# Altium schematic sheet Triangular_Wave.SchDoc(Triangular_Wave)
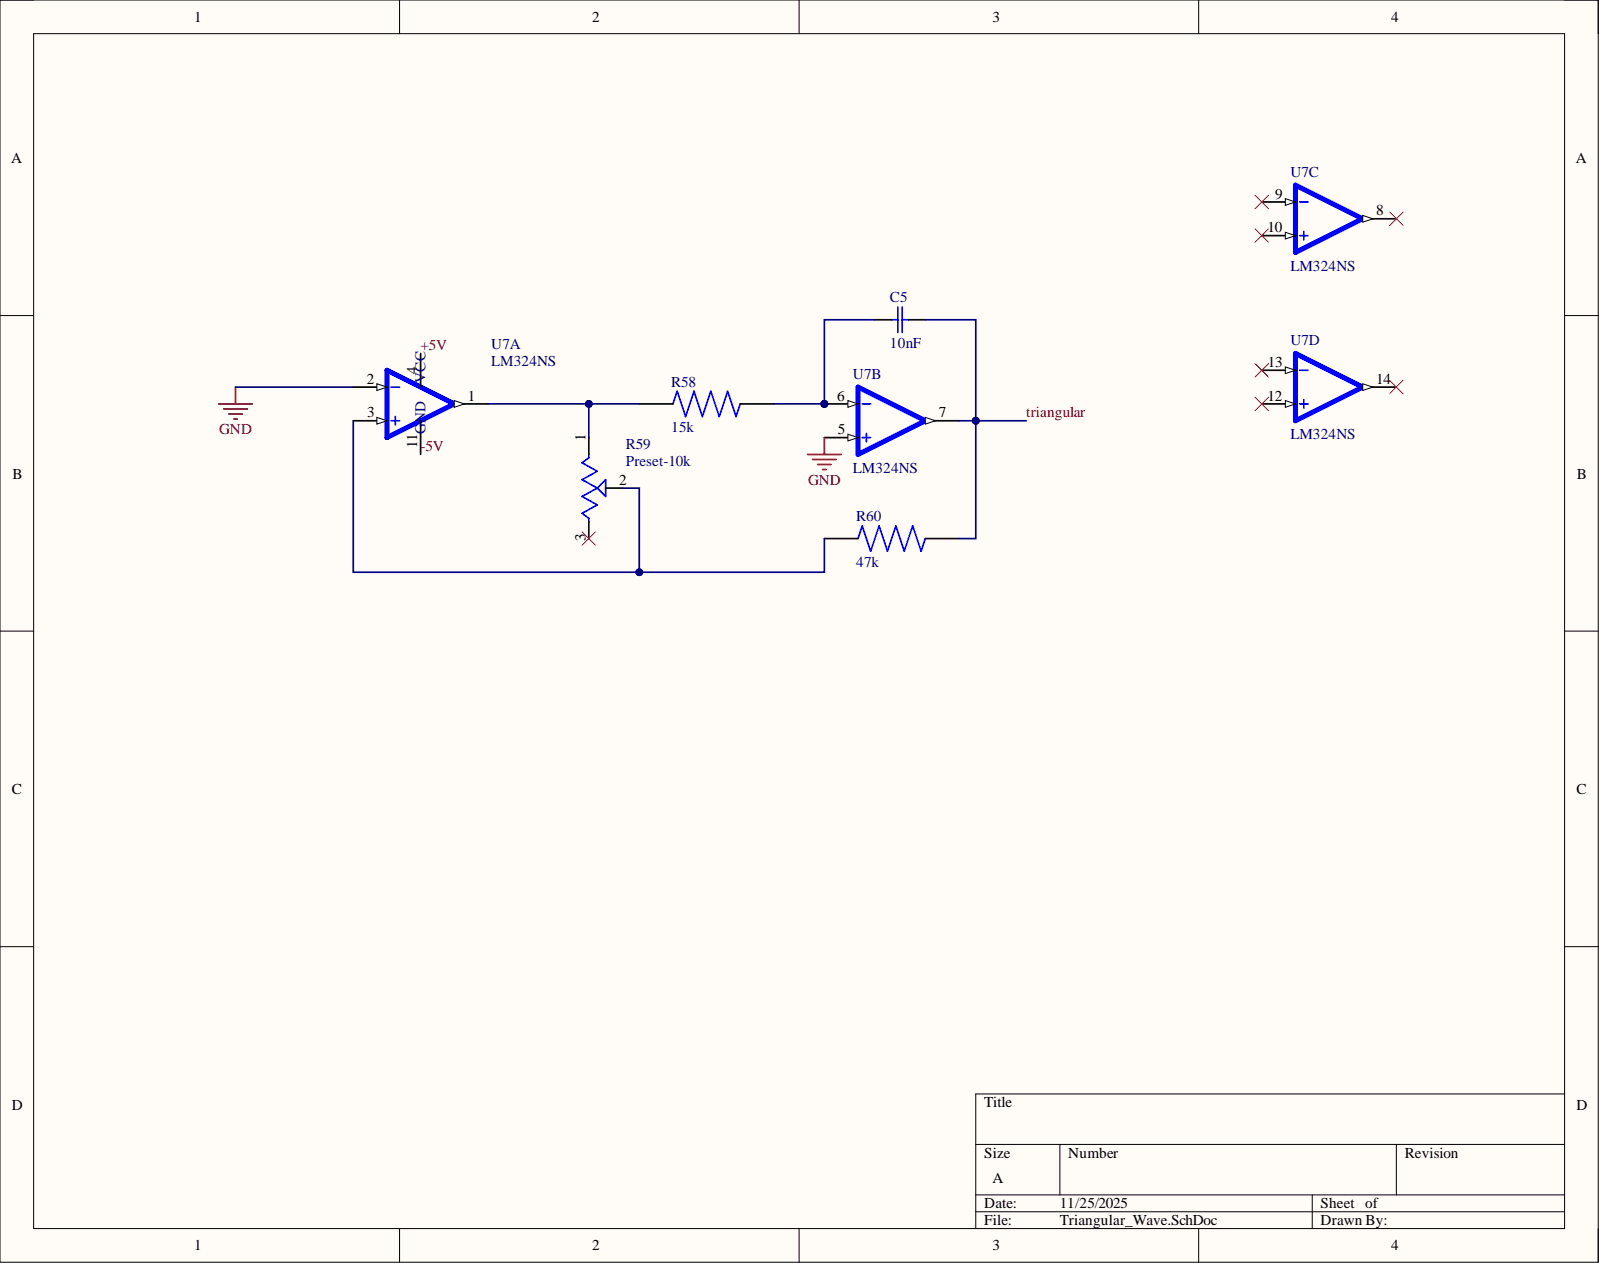
[diagram p0: Triangular_Wave.SchDoc]

## Parts
C5  10nF
R58  15k
R59  Preset-10k
R60  47k
U7  LM324NS  (parts A,B,C,D)

## Nets
GND: U7.2 U7.5
+5V: U7.4(GND)
-5V: U7.11
triangular: C5.2 R60.2 U7.7
NetC5_1: C5.1 R58.2 U7.6
NetR58_1: R58.1 R59.1 U7.1
NetR59_2: R59.2 R60.1 U7.3

Not connected: R59 3; U7 8 9 10 12 13 14

## Library data of the parts
C5 = 10nF: 10000 pF Â±10% 50V Ceramic Capacitor X7R 0805 (2012 Metric); CC0805KRX7R9BB103; MF: Yageo; Check_prices: https://www.snapeda.com/parts/CC0805KRX7R9BB103/Yageo/view-part/?ref=eda; Component Kind: Standard; MP: CC0805KRX7R9BB103; Package: 0805 Yageo; SnapEDA_Link: https://www.snapeda.com/parts/CC0805KRX7R9BB103/Yageo/view-part/?ref=snap; Library Name: 10nfs.IntLib
R58 = 15k: ERA6ARB153V; Availability: Unavailable; Component Kind: Standard; Library Name: 15ks.IntLib; MP: ERA6ARB153V; Package: None; Price: None
R59 = Preset: TRIMMER - 6MM ROUND, SINGLE-TURN,CERMET, 10K OHMS | Bourns 3306F-1-103; Check_prices: https://www.snapeda.com/parts/3306F-1-103/Bourns/view-part/?ref=eda; Component Kind: Standard; Library Name: 3306F-1-103.IntLib; MF: Bourns; MP: 3306F-1-103; Package: 3306F Bourns; SnapEDA_Link: https://www.snapeda.com/parts/3306F-1-103/Bourns/view-part/?ref=snap
R60 = 47k: 47 kOhms Â±1% 0.125W, 1/8W Chip Resistor 0805 (2012 Metric) Moisture Resistant Thick Film; RC0805FR-0747KL; MF: Yageo; Check_prices: https://www.snapeda.com/parts/RC0805FR-0747KL/Yageo/view-part/?ref=eda; Component Kind: Standard; Library Name: 47ks.IntLib; MP: RC0805FR-0747KL; Package: 2012 Yageo; SnapEDA_Link: https://www.snapeda.com/parts/RC0805FR-0747KL/Yageo/view-part/?ref=snap
U7 = LM324NS: 4-Channel industry standard operational amplifier 14-SO 0 to 70; LM324NSRG4; MF: Texas Instruments; Availability: In Stock; Check_prices: https://www.snapeda.com/parts/LM324NSRG4/Texas+Instruments/view-part/?ref=eda; Component Kind: Standard; Library Name: LM324NSRG4.IntLib; MP: LM324NSRG4; Package: SOP-14 Texas Instruments; Price: None; Purchase-URL: https://pricing.snapeda.com/search/part/LM324NSRG4/?ref=eda; SnapEDA_Link: https://www.snapeda.com/parts/LM324NSRG4/Texas+Instruments/view-part/?ref=snap
